# Altium schematic sheet Clock.SchDoc(Clock)
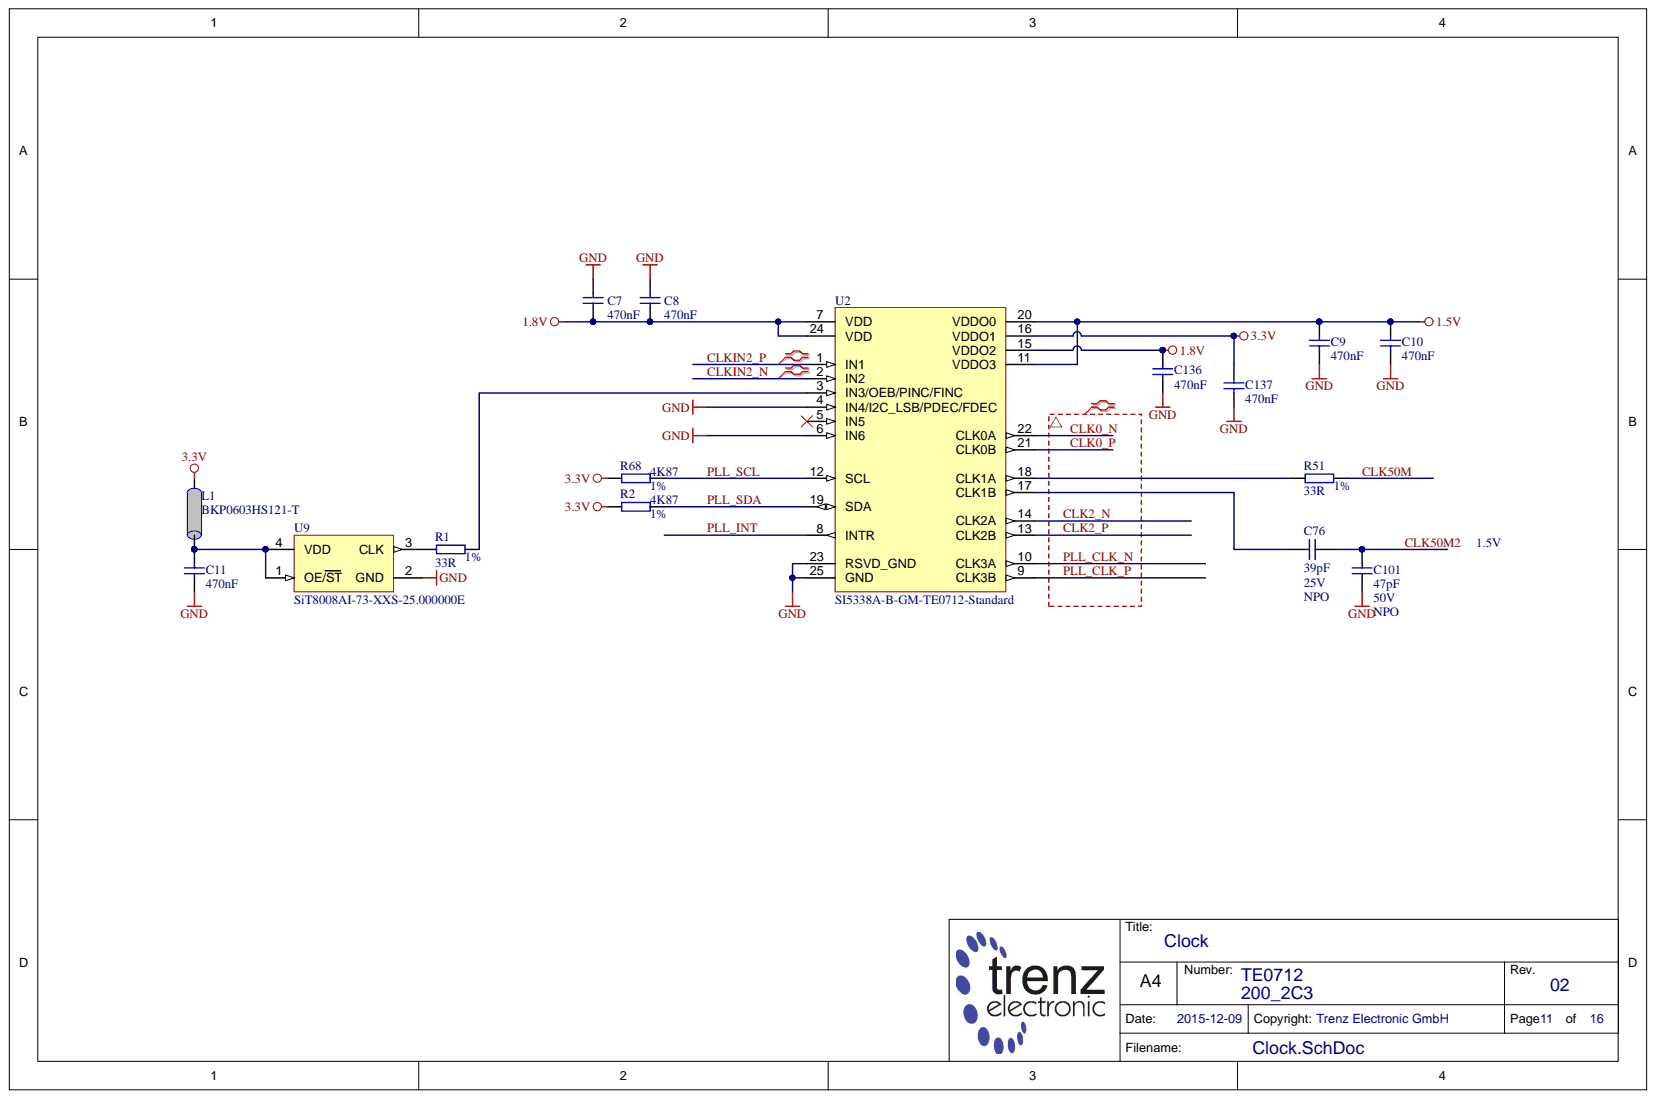
[diagram p0: Clock.SchDoc]

## Parts
C7  470nF  [CAPC0603X33N]
C8  470nF  [CAPC0603X33N]
C9  470nF  [CAPC0603X33N]
C10  470nF  [CAPC0603X33N]
C11  470nF  [CAPC0603X33N]
C76  39pF  Voltage: 25V  [CAPC1005X55N]
C101  47pF  Technology: NPO  Voltage: 50V  [CAPC1005X55N]
C136  470nF  [CAPC0603X33N]
C137  470nF  [CAPC0603X33N]
L1  BKP0603HS121-T  [INDC0603X33N]
R1  33R  Tolerance: 1%  [RESC0603X26N]
R2  4K87  Tolerance: 1%  [RESC1005X40N]
R51  33R  Tolerance: 1%  [RESC0603X26N]
R68  4K87  Tolerance: 1%  [RESC1005X40N]
U2  SI5338A-B-GM-TE0712-01-100-2C2  [QFN50P400X400X90-25N]
U2  SI5338A-B-GM-TE0712-Standard  [QFN50P400X400X90-25N]
U9  SiT8008AI-73-XXS-25.000000E  Database Table Name: CLK  [2016]

## Nets
1.5V: C9.1 C10.1 U2.11 U2.20
1.8V: C7.1 C8.1 C136.1 U2.7 U2.15 U2.24
3.3V: C137.1 L1.1 R2.1 R68.1 U2.16
GND: C7.2 C8.2 C9.2 C10.2 C11.2 C101.2 C136.2 C137.2 U2.4 U2.6 U2.23 U2.25 U9.2(GND)
CLK0_N: U2.22
CLK0_P: U2.21
CLK2_N: U2.14
CLK2_P: U2.13
CLK50M: R51.2
CLK50M2: C76.2 C101.1
CLKIN2_N: U2.2
CLKIN2_P: U2.1
PLL_CLK_N: U2.10
PLL_CLK_P: U2.9
PLL_INT: U2.8
PLL_SCL: R68.2 U2.12
PLL_SDA: R2.2 U2.19
NetC11_1: C11.1 L1.2 U9.1(OE/ST) U9.4(VDD)
NetC76_1: C76.1 U2.17
NetR1_1: R1.1 U9.3(CLK)
NetR1_2: R1.2 U2.3
NetR51_1: R51.1 U2.18
N00524:

Not connected: U2 5

## Library data of the parts
C7-C11 C136 C137 = 24921: KON 470nF X5R 6.3V SMD 20%; Database Table Name: C_0603_0201; Mixed: SMT; Preferred: Yes; Value: 470n; AN: 24921; Case: 0603 (0201); Component Kind: Standard; Library Name: TE.DbLib; Technology: X5R; Tolerance: 20%; Voltage: 6.3V
C76 = 23753: KON 39pF NPO 50V SMD; Database Table Name: C_1005_0402; Mixed: SMT; Value: 39p; AN: 23753; Case: 1005 (0402); Component Kind: Standard; Library Name: TE.DbLib; Technology: NPO
C101 = 24885: KON 47pF NPO 50V SMD; Database Table Name: C_1005_0402; Mixed: SMT; Preferred: Yes; Value: 47p; AN: 24885; Case: 1005 (0402); Component Kind: Standard; Library Name: TE.DbLib
L1 = 25393: Ferritdurchführung SMD 0201; Database Table Name: L_0603_0201; Mixed: SMT; Preferred: Yes; Tolerance: 25%; AN: 25393; Case: 0603 (0201); Component Kind: Standard; H-ART: BKP0603HS121-T; Library Name: TE.DbLib; Trenz-Stock: Yes; HelpURL: B:\AD\adlib\datasheet\MULTILAYER CHIP BEAD INDUCTORS BK
R1 R51 = 25021: WID SMD 33R 1% 0,05W; Database Table Name: R_0603_0201; Mixed: SMT; Preferred: Yes; Value: 33; AN: 25021; Case: 0603 (0201); Component Kind: Standard; Library Name: TE.DbLib; HelpURL: B:\AD\adlib\datasheet\R0201
R2 R68 = 25921: WID SMD 4K87 1% 0,063W; Database Table Name: R_1005_0402; Mixed: SMT; Preferred: Yes; Value: 4.87k; AN: 25921; Case: 1005 (0402); Component Kind: Standard; Library Name: TE.DbLib
U2 = 26615: Database Table Name: CUSTOMIZED; Mixed: SMT; Trenz-Stock: Yes; AN: 26615; Case: QFN24; Component Kind: Standard; Description: SI5338A-B-GM-TE0712-01-100-2C2; Library Name: TE.DbLib; HelpURL: B:\AD\adlib\datasheet\SI5338A
U2 = 26008: Database Table Name: CUSTOMIZED; Mixed: SMT; Trenz-Stock: Yes; AN: 26008; Case: QFN24; Component Kind: Standard; Description: SI5338A-B-GM-TE0712-Standard; Library Name: TE.DbLib; HelpURL: B:\AD\adlib\datasheet\SI5338A
U9 = 25008: SiT8008AI-73-XXS-25.000000E MEMS Oszillator 25MHz; Mixed: SMT; Preferred: Yes; Value: 25M; AN: 25008; Case: 2.0  x 1.6mm; Component Kind: Standard; Library Name: TE.DbLib; Trenz-Stock: Yes; HelpURL: B:\AD\adlib\datasheet\SiT8008
